# Altium schematic sheet Arak-AnalogueFrontend.SchDoc
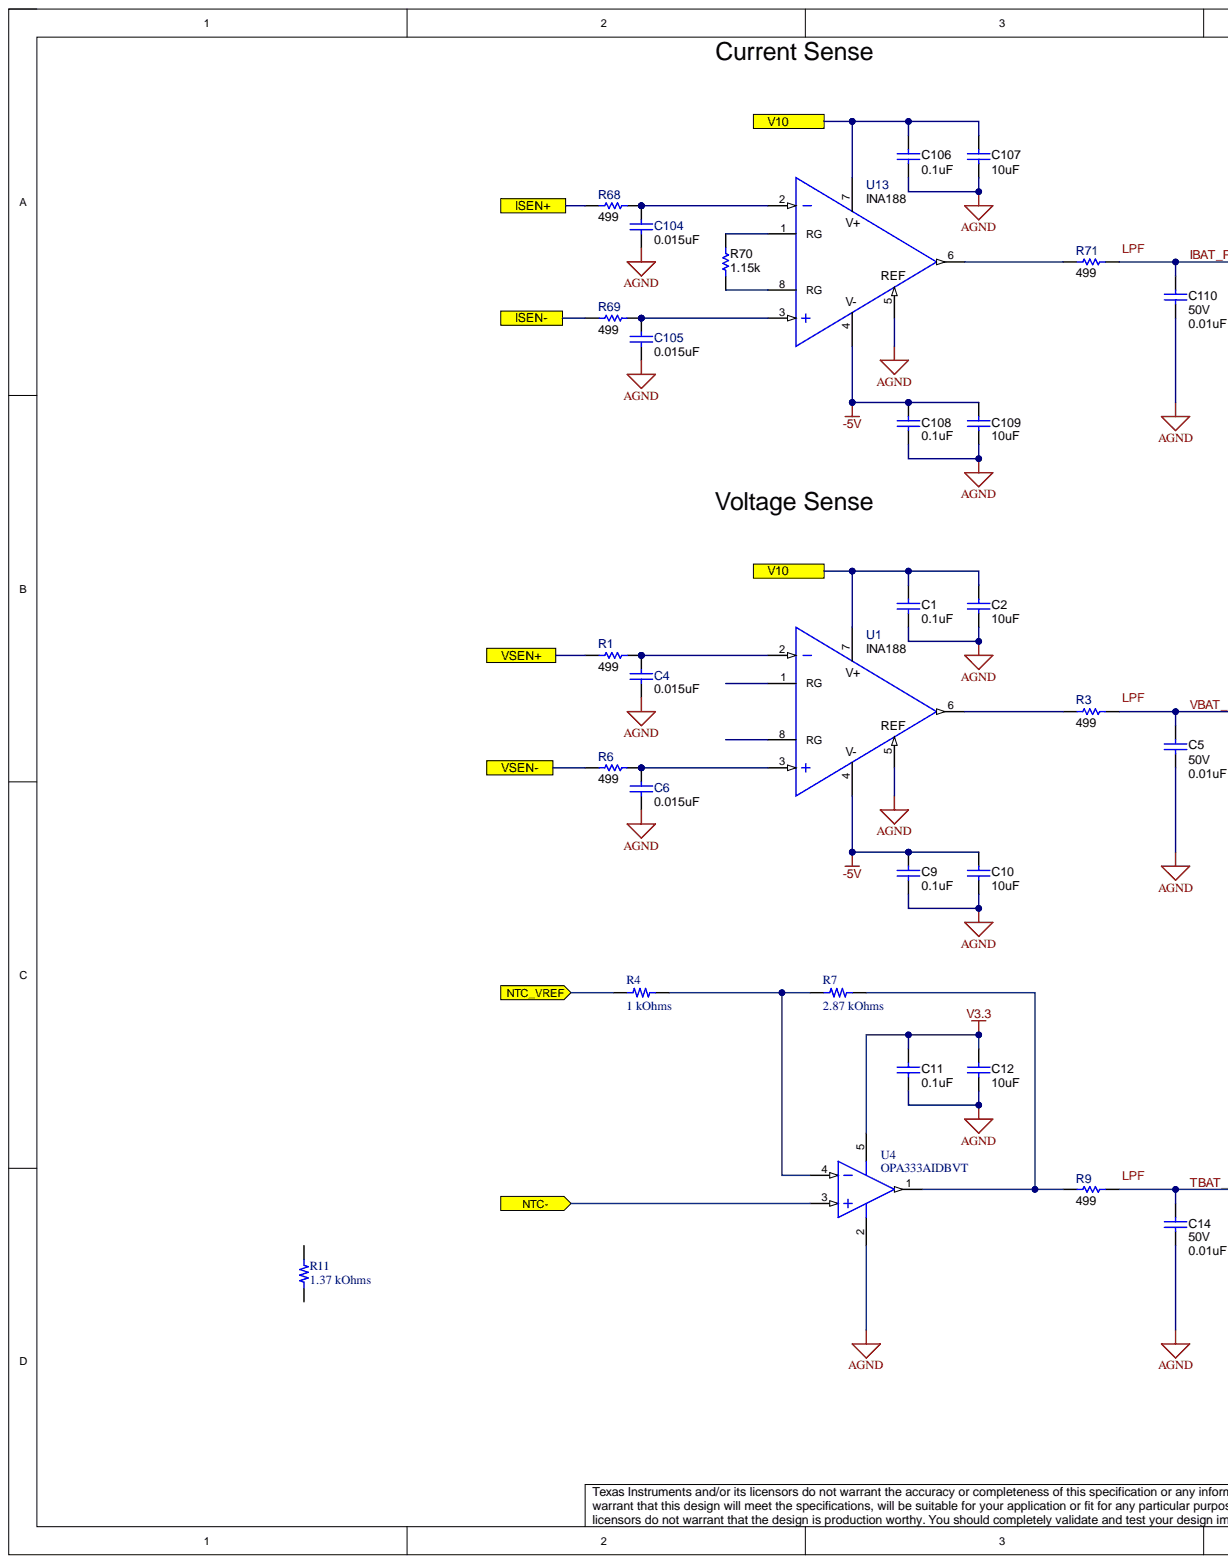
[diagram p0: Arak-AnalogueFrontend.SchDoc, left]
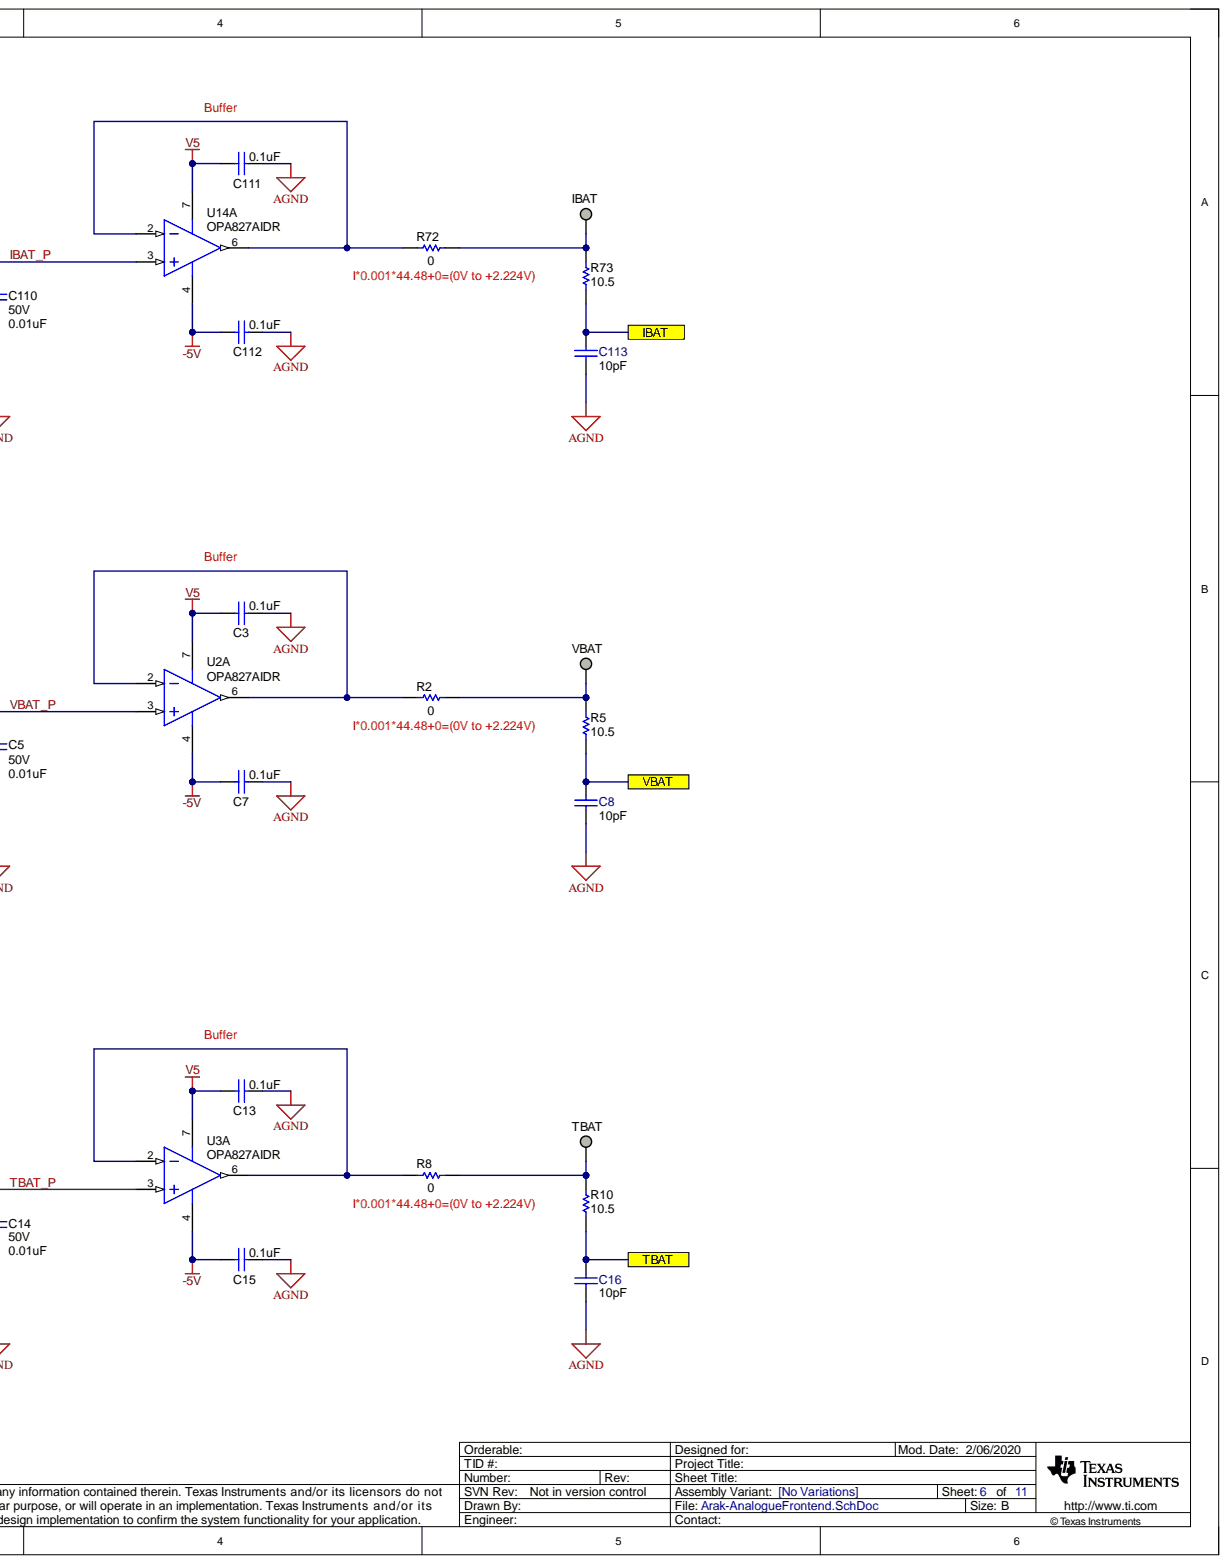
[diagram p1: Arak-AnalogueFrontend.SchDoc, right]

## Parts
C1  value 0.1uF  [0603]  (hidden comment: Capacitor)
C2  value 10uF  [0805_HV]  (hidden comment: Capacitor)
C3  value 0.1uF  [0603]  (hidden comment: Capacitor)
C4  value 0.015uF  [0603]  (hidden comment: Capacitor)
C5  value 0.01uF  Value2: 50V  [0603]  (hidden comment: GCM188R71H103KA37D)
C6  value 0.015uF  [0603]  (hidden comment: Capacitor)
C7  value 0.1uF  [0603]  (hidden comment: Capacitor)
C8  value 10pF  Cap_F: 10pF  [0603]  (hidden comment: Capacitor)
C9  value 0.1uF  [0603]  (hidden comment: Capacitor)
C10  value 10uF  [0805_HV]  (hidden comment: Capacitor)
C11  value 0.1uF  [0603]  (hidden comment: Capacitor)
C12  value 10uF  [0805_HV]  (hidden comment: Capacitor)
C13  value 0.1uF  [0603]  (hidden comment: Capacitor)
C14  value 0.01uF  Value2: 50V  [0603]  (hidden comment: GCM188R71H103KA37D)
C15  value 0.1uF  [0603]  (hidden comment: Capacitor)
C16  value 10pF  Cap_F: 10pF  [0603]  (hidden comment: Capacitor)
C104  value 0.015uF  [0603]  (hidden comment: Capacitor)
C105  value 0.015uF  [0603]  (hidden comment: Capacitor)
C106  value 0.1uF  [0603]  (hidden comment: Capacitor)
C107  value 10uF  [0805_HV]  (hidden comment: Capacitor)
C108  value 0.1uF  [0603]  (hidden comment: Capacitor)
C109  value 10uF  [0805_HV]  (hidden comment: Capacitor)
C110  value 0.01uF  Value2: 50V  [0603]  (hidden comment: GCM188R71H103KA37D)
C111  value 0.1uF  [0603]  (hidden comment: Capacitor)
C112  value 0.1uF  [0603]  (hidden comment: Capacitor)
C113  value 10pF  Cap_F: 10pF  [0603]  (hidden comment: Capacitor)
IBAT  Pogo Pad  [PogoPad]  (value not drawn)  (no BOM)
R1  value 499  [0603]  (hidden comment: Resistor)
R2  value 0  [0603]  (hidden comment: Resistor)
R3  value 499  [0603]  (hidden comment: Resistor)
R4  1 kOhms  [RES 0603_1608]
R5  value 10.5  Res_ohm: 10.5  [0603]  (hidden comment: RC0603FR-0710R5L)
R6  value 499  [0603]  (hidden comment: Resistor)
R7  2.87 kOhms  [RES 0603_1608]
R8  value 0  [0603]  (hidden comment: Resistor)
R9  value 499  [0603]  (hidden comment: Resistor)
R10  value 10.5  Res_ohm: 10.5  [0603]  (hidden comment: RC0603FR-0710R5L)
R11  1.37 kOhms  [RES 0603_1608]
R68  value 499  [0603]  (hidden comment: Resistor)
R69  value 499  [0603]  (hidden comment: Resistor)
R70  1.15k  [0603]
R71  value 499  [0603]  (hidden comment: Resistor)
R72  value 0  [0603]  (hidden comment: Resistor)
R73  value 10.5  Res_ohm: 10.5  [0603]  (hidden comment: RC0603FR-0710R5L)
TBAT  Pogo Pad  [PogoPad]  (value not drawn)  (no BOM)
U1  INA188  [D0008A_N]
U2  OPA827AIDR  [D0008A_L]  (part A)
U3  OPA827AIDR  [D0008A_L]  (part A)
U4  OPA333AIDBVT  [DBV0005A_M]
U13  INA188  [D0008A_N]
U14  OPA827AIDR  [D0008A_L]  (part A)
VBAT  Pogo Pad  [PogoPad]  (value not drawn)  (no BOM)

## Ports
IBAT, ISEN+, ISEN-, NTC-, NTC_VREF, TBAT, V10, VBAT, VSEN+, VSEN-

## Nets
-5V: C7.1 C9.1 C10.1 C15.1 C108.1 C109.1 C112.1 U1.4(V-) U2.4 U3.4 U13.4(V-) U14.4
AGND: C1.2 C2.2 C3.2 C4.2 C5.2 C6.2 C7.2 C8.1 C9.2 C10.2 C11.2 C12.2 C13.2 C14.2 C15.2 C16.1 C104.2 C105.2 C106.2 C107.2 C108.2 C109.2 C110.2 C111.2 C112.2 C113.1 U1.5 U4.2 U13.5
V3.3: C11.1 C12.1 U4.5
V5: C3.1 C13.1 C111.1 U2.7 U3.7 U14.7
IBAT: C113.2 R73.1 ; port IBAT
IBAT_P: C110.1 R71.1 U14.3
NTC-: U4.3 ; port NTC-
NTC_VREF: R4.1 ; port NTC_VREF
TBAT: C16.2 R10.1 ; port TBAT
TBAT_P: C14.1 R9.1 U3.3
V10: C1.1 C2.1 C106.1 C107.1 U1.7(V+) U13.7(V+) ; port V10
VBAT: C8.2 R5.1 ; port VBAT
VBAT_P: C5.1 R3.1 U2.3
NetC4_1: C4.1 R1.1 U1.2
NetC6_1: C6.1 R6.1 U1.3
NetC104_1: C104.1 R68.1 U13.2
NetC105_1: C105.1 R69.1 U13.3
NetIBAT_1: IBAT.1 R72.2 R73.2
NetR1_2: R1.2
NetR2_1: R2.1 U2.2 U2.6
NetR2_2: R2.2 R5.2 VBAT.1
NetR3_2: R3.2 U1.6
NetR4_2: R4.2 R7.1 U4.4
NetR6_2: R6.2
NetR7_2: R7.2 R9.2 U4.1
NetR8_1: R8.1 U3.2 U3.6
NetR8_2: R8.2 R10.2 TBAT.1
NetR68_2: R68.2
NetR69_2: R69.2
NetR70_1: R70.1 U13.8(RG)
NetR70_2: R70.2 U13.1(RG)
NetR71_2: R71.2 U13.6
NetR72_1: R72.1 U14.2 U14.6

Not connected: R11 1 2; U1 1(RG) 8(RG)

## Library data of the parts
C1 C3 C7 C9 C11 C13 C15 C106 C108 C111 C112 = CMP-0006752-5: CAP, CERM, 0.1 uF, 25 V, +/- 5%, X7R, 0603; Manufacturer: AVX; PartNumber: 06033C104JAT2A; AEC Category: Q200; AEC Qualified: N; Cap_F: 100nF; ESR_ohm: 0.05; SizeArea_mm2: 1.28; SizeAreaWB_mm2: 4.68; SizeX_mm: 1.6; SizeY_mm: 0.8; SizeZ_mm: 1; TaMax_C: 125°C; TaMin_C: -55°C; U/M: EA; Value1: 0.05 ohm; Value2: 25V; AEC Grade: N/A; Cap_Case: 0603; Component Kind: Standard; ComponentLink1Description: Datasheet link; ComponentLink1URL: http://datasheets.avx.com/X7RDielectric.pdf; CompType: Capacitor; LatestRevisionDate: 2017-03-09; Library Name: Altium Vault - TI; MountType: SMT; PackageReference: 0603; Polarized: N; Published: 2008-06-19; RoHS: Y; Supplier 1: Digi-Key; Supplier 2: Mouser; Supplier 3: Farnell; Supplier Part Number 1: 478-3713-1-ND; Supplier Part Number 2: 581-06033C104JAT2A; Supplier Part Number 3: 1740614; Technology: Ceramic; TempCo: X7R; Tolerance_%: 5%; ValueDisplayed: 0.1uF; VDC_V: 25V; Publisher: Texas Instruments
C2 C10 C12 C107 C109 = CMP-0008315-2: CAP, CERM, 10 uF, 10 V, +/- 10%, X7R, 0805; Manufacturer: MuRata; PartNumber: GRM21BR71A106KE51L; AEC Category: Q200; Cap_Case: 0805; Cap_F: 10µF; ESR_ohm: 3m; Polarized: N; SizeArea_mm2: 2.5; SizeAreaWB_mm2: 6.75; SizeX_mm: 2; SizeY_mm: 1.25; SizeZ_mm: 1.45; TaMax_C: 125°C; TaMin_C: -55°C; U/M: EA; Value1: 0.003 ohm; Value2: 10V; AEC Qualified: ?; Component Kind: Standard; ComponentLink1Description: Datasheet link; ComponentLink1URL: http://search.murata.co.jp/Ceramy/PnsearchViewAction.do?HnOFG=ON&HnNFG=ON&SEL_CNT=50&sLang=en&sKey=GRM21BR71A106KE51L&x=9&y=9&search=startwith; CompType: Capacitor; LatestRevisionDate: 2017-03-09; Library Name: Altium Vault - TI; MountType: SMT; PackageReference: 0805; Published: 2008-06-19; RoHS: Y; Supplier 1: Digi-Key; Supplier 2: Mouser; Supplier 3: Farnell; Supplier Part Number 1: 490-3905-1-ND; Supplier Part Number 2: 81-GRM21BR71A106KE51; Supplier Part Number 3: 1828828; Technology: Ceramic; TempCo: X7R; Tolerance_%: 10%; ValueDisplayed: 10uF; VDC_V: 10V; Publisher: Texas Instruments
C4 C6 C104 C105 = CMP-0007383-5: CAP, CERM, 0.015 uF, 16 V, +/- 10%, X7R, 0603; Manufacturer: MuRata; PartNumber: GRM188R71C153KA01D; AEC Category: Q200; Cap_F: 15nF; Polarized: N; SizeArea_mm2: 1.28; SizeAreaWB_mm2: 4.68; SizeX_mm: 1.6; SizeY_mm: 0.8; SizeZ_mm: 1; TaMax_C: 125°C; TaMin_C: -55°C; U/M: EA; Value1: Unknown; Value2: 16V; AEC Qualified: ?; Cap_Case: 0603; Component Kind: Standard; ComponentLink1Description: Datasheet link; ComponentLink1URL: http://search.murata.co.jp/Ceramy/PnsearchViewAction.do?HnOFG=ON&HnNFG=ON&SEL_CNT=50&sLang=en&sKey=GRM188R71C153KA01D&x=9&y=9&search=startwith; CompType: Capacitor; LatestRevisionDate: 2017-03-09; Library Name: Altium Vault - TI; MountType: SMT; PackageReference: 0603; Published: 2008-06-19; RoHS: Y; Supplier 1: Mouser; Supplier Part Number 1: 81-GRM188R71C153KA; Technology: Ceramic; TempCo: X7R; Tolerance_%: 10%; ValueDisplayed: 0.015uF; VDC_V: 16V; Publisher: Texas Instruments
C5 C14 C110 = CMP-0007143-4: CAP, CERM, 0.01 uF, 50 V, +/- 10%, X7R, AEC-Q200 Grade 1, 0603; Manufacturer: MuRata; AEC Category: Q200; AEC Grade: 1; AEC Qualified: Y; Cap_F: 10nF; CompType: Capacitor; Polarized: N; SizeArea_mm2: 1.28; SizeAreaWB_mm2: 4.68; SizeX_mm: 1.6; SizeY_mm: 0.8; TaMax_C: 125°C; TaMin_C: -55°C; U/M: EA; Value1: Unknown; Cap_Case: 0603; Component Kind: Standard; ComponentLink1Description: Datasheet link; ComponentLink1URL: http://psearch.en.murata.com/capacitor/product/GCM188R71H103KA37%23.pdf; LatestRevisionDate: 2017-03-09; Library Name: Altium Vault - TI; MountType: SMT; PackageReference: 0603; PartNumber: GCM188R71H103KA37D; Published: 2008-06-19; RoHS: Y; SizeZ_mm: 1; Supplier 1: Digi-Key; Supplier 2: Newark; Supplier 3: Mouser; Supplier Part Number 1: 490-4778-1-ND; Supplier Part Number 2: 45Y5166; Supplier Part Number 3: 81-GCM188R71H103KA7D; Technology: Ceramic; TempCo: X7R; Tolerance_%: 10%; ValueDisplayed: 0.01uF; VDC_V: 50V; Publisher: Texas Instruments
C8 C16 C113 = CMP-0007170-4: CAP, CERM, 10 pF, 50 V, +/- 5%, C0G/NP0, 0603; Manufacturer: MuRata; PartNumber: GRM1885C1H100JA01D; AEC Category: Q200; Polarized: N; SizeArea_mm2: 1.28; SizeAreaWB_mm2: 4.68; SizeX_mm: 1.6; SizeY_mm: 0.8; SizeZ_mm: 1; TaMax_C: 125°C; TaMin_C: -55°C; U/M: EA; Value1: Unknown; Value2: 50V; AEC Qualified: ?; Cap_Case: 0603; Component Kind: Standard; ComponentLink1Description: Datasheet link; ComponentLink1URL: http://search.murata.co.jp/Ceramy/PnsearchViewAction.do?HnOFG=ON&HnNFG=ON&SEL_CNT=50&sLang=en&sKey=GRM1885C1H100JA01D&x=9&y=9&search=startwith; CompType: Capacitor; LatestRevisionDate: 2017-03-09; Library Name: Altium Vault - TI; MountType: SMT; PackageReference: 0603; Published: 2008-06-19; RoHS: Y; Supplier 1: Digi-Key; Supplier 2: Mouser; Supplier 3: Farnell; Supplier Part Number 1: 490-1403-1-ND; Supplier Part Number 2: 81-GRM39C100D50; Supplier Part Number 3: 8819815; Technology: Ceramic; TempCo: C0G/NP0; Tolerance_%: 5%; ValueDisplayed: 10pF; VDC_V: 50V; Publisher: Texas Instruments
IBAT TBAT VBAT = PogoPad: Pad for Pogo Pin Connector; SymbolCreator: codlsc; CompType: Pogo Pad; LatestRevisionDate: 2016-12-05; LatestRevisionNote: Added Alternate PartNumber and Alternate Manufacturer parameters to appropriate components.; Library Name: MiscParts.IntLib; PackageReference: N/A; SymbolVersion: 2010-09-27; Publisher: Texas Instruments
R1 R3 R6 R9 R68 R69 R71 = CMP-0022446-5: RES, 499, 1%, 0.1 W, AEC-Q200 Grade 0, 0603; Manufacturer: Vishay-Dale; PartNumber: CRCW0603499RFKEA; AEC Category: Q200; AEC Grade: 0; AEC Qualified: Y; EIA_Code: E96; Power_W: 100mW; SizeArea_mm2: 1.28; SizeX_mm: 1.6; SizeY_mm: 0.8; SizeZ_mm: 1; TempCo: 100 ppm/C; Value2: 0.1W; Value_Code: 499R; VDC_V: 75V; Component Kind: Standard; ComponentLink1Description: Datasheet link; ComponentLink1URL: http://www.vishay.com/docs/20035/dcrcwe3.pdf; CompType: Resistor; Library Name: Altium Vault - TI; MountType: SMT; PackageReference: 0603; Res_ohm: 499; RoHS: E; Supplier 1: Digi-Key; Supplier 2: Newark; Supplier 3: Mouser; Supplier Part Number 1: 541-499HCT-ND; Supplier Part Number 2: 52K8569; Supplier Part Number 3: 71-CRCW0603-499-E3; Technology: Thick Film; Tolerance_%: 1%; Value1: 1%; ValueDisplayed: 499
R2 R8 R72 = CMP-0025874-3: RES, 0, 5%, 0.1 W, 0603; Manufacturer: Panasonic; PartNumber: ERJ-3GEY0R00V; AEC Category: Q200; EIA_Code: E24; Power_W: 100mW; SizeArea_mm2: 1.28; SizeX_mm: 1.6; SizeY_mm: 0.8; SizeZ_mm: 1; TempCo: 600 ppm/C; U/M: EA; Value2: 0.1W; Value_Code: 0000; VDC_V: 50V; AEC Qualified: ?; Component Kind: Standard; ComponentLink1Description: Datasheet link; ComponentLink1URL: http://www.panasonic.com/industrial/components/pdf/AOA0000CE1.pdf; CompType: Resistor; LatestRevisionDate: 2017-03-09; Library Name: Altium Vault - TI; MountType: SMT; PackageReference: 0603; Published: 2008-06-26; Res_ohm: 0; RoHS: Y; Supplier 1: Digi-Key; Supplier 2: Mouser; Supplier 3: Farnell; Supplier Part Number 1: P0.0GCT-ND; Supplier Part Number 2: 667-ERJ-3GEY0R00V; Supplier Part Number 3: 2059527; Technology: Thick Film; Tolerance_%: 5%; Value1: 5%; ValueDisplayed: 0; Publisher: Texas Instruments
R4 = TNPW06031K00BYEN: RES 1K OHM 0.1% 1/8W 0603; Manufacturer: Vishay Dale; Database Table Name: Resistors - Surface Mount - 0603; Power (Watts): 0.125W, 1/8W; Temperature Coefficient: ±10ppm/°C; Component Kind: Standard; ComponentLink1Description: Datasheet; ComponentLink1URL: http://www.vishay.com/docs/28758/tnpw_e3.pdf; ComponentLink2Description: DigiKey Link; ComponentLink2URL: http://digikey.com/product-detail/en/vishay-dale/TNPW06031K00BYEN/541-4408-1-ND/8629044; Composition: Thin Film; Device Package: 0603 (1608 Metric); Features: Anti-Sulfur, Automotive AEC-Q200, Moisture Resistant; Height - Seated (Max): 0.022" (0.55mm); LastUpdated: 21/01/2020 12:18:21 AM; Library Name: Celestial Altium Library - altium_library.DbLib; Lifecycle Status: Active; Minimum Order: 1; Number of Terminations: 2; Operating Temperature: -55°C ~ 155°C; Package / Case: 0603 (1608 Metric); Packaging: TapeAndReel; Part Number: TNPW06031K00BYEN; Part Status: Active; PartId: 21027; Price: 0.27; Ratings: AEC-Q200; Resistance: 1 kOhms; Series: TNPW e3; Size / Dimension: 0.061" L x 0.033" W (1.55mm x 0.85mm); Supplier 1: DigiKey; Supplier Device Package: 0603; Supplier Part Number 1: 541-4408-1-ND; Tolerance: ±0.1%; Value: 1 kOhms
R5 R10 R73 = CMP-0022749-2: RES, 10.5, 1%, 0.1 W, 0603; Manufacturer: Yageo America; AEC Category: Q200; CompType: Resistor; EIA_Code: E96; Power_W: 100mW; SizeArea_mm2: 1.28; SizeX_mm: 1.6; SizeY_mm: 0.8; SizeZ_mm: 1; TempCo: 200 ppm/C; U/M: EA; Value2: 0.1W; Value_Code: 10R5; VDC_V: 150V; AEC Qualified: ?; Component Kind: Standard; ComponentLink1Description: Datasheet link; ComponentLink1URL: http://www.yageo.com/pdf/Pu-RC0603_51_PbFree_L_2.pdf; LatestRevisionDate: 2017-03-09; Library Name: Altium Vault - TI; MountType: SMT; PackageReference: 0603; PartNumber: RC0603FR-0710R5L; Published: 2008-06-26; RoHS: Y; Supplier 1: Digi-Key; Supplier 2: Mouser; Supplier 3: Farnell; Supplier Part Number 1: 311-10.5HRCT-ND; Supplier Part Number 2: 603-RC0603FR-0710R5L; Supplier Part Number 3: 9238247; Technology: Thick Film; Tolerance_%: 1%; Value1: 1%; ValueDisplayed: 10.5; Publisher: Texas Instruments
R7 = TNPW06032K87BEEA: RES 2.87K OHM 0.1% 1/8W 0603; Manufacturer: Vishay Dale; Database Table Name: Resistors - Surface Mount - 0603; Power (Watts): 0.125W, 1/8W; Temperature Coefficient: ±25ppm/°C; Component Kind: Standard; ComponentLink1Description: Datasheet; ComponentLink1URL: http://www.vishay.com/docs/28758/tnpw_e3.pdf; ComponentLink2Description: DigiKey Link; ComponentLink2URL: http://digikey.com/product-detail/en/vishay-dale/TNPW06032K87BEEA/541-3180-1-ND/6532822; Composition: Thin Film; Device Package: 0603 (1608 Metric); Features: Anti-Sulfur, Automotive AEC-Q200, Moisture Resistant; Height - Seated (Max): 0.022" (0.55mm); LastUpdated: 21/01/2020 12:17:06 AM; Library Name: Celestial Altium Library - altium_library.DbLib; Lifecycle Status: Active; Minimum Order: 1; Number of Terminations: 2; Operating Temperature: -55°C ~ 155°C; Package / Case: 0603 (1608 Metric); Packaging: TapeAndReel; Part Number: TNPW06032K87BEEA; Part Status: Active; PartId: 23190; Price: 0.11; Ratings: AEC-Q200; Resistance: 2.87 kOhms; Series: TNPW e3; Size / Dimension: 0.063" L x 0.033" W (1.60mm x 0.85mm); Supplier 1: DigiKey; Supplier Device Package: 0603; Supplier Part Number 1: 541-3180-1-ND; Tolerance: ±0.1%; Value: 2.87 kOhms
R11 = TNPW06031K37BEEA: RES 1.37K OHM 0.1% 1/8W 0603; Manufacturer: Vishay Dale; Database Table Name: Resistors - Surface Mount - 0603; Power (Watts): 0.125W, 1/8W; Temperature Coefficient: ±25ppm/°C; Component Kind: Standard; ComponentLink1Description: Datasheet; ComponentLink1URL: http://www.vishay.com/docs/28758/tnpw_e3.pdf; ComponentLink2Description: DigiKey Link; ComponentLink2URL: http://digikey.com/product-detail/en/vishay-dale/TNPW06031K37BEEA/541-3048-1-ND/6073691; Composition: Thin Film; Device Package: 0603 (1608 Metric); Features: Anti-Sulfur, Automotive AEC-Q200, Moisture Resistant; Height - Seated (Max): 0.022" (0.55mm); LastUpdated: 21/01/2020 12:14:57 AM; Library Name: Celestial Altium Library - altium_library.DbLib; Lifecycle Status: Active; Minimum Order: 1; Number of Terminations: 2; Operating Temperature: -55°C ~ 155°C; Package / Case: 0603 (1608 Metric); Packaging: TapeAndReel; Part Number: TNPW06031K37BEEA; Part Status: Active; PartId: 25753; Price: 0.11; Ratings: AEC-Q200; Resistance: 1.37 kOhms; Series: TNPW e3; Size / Dimension: 0.063" L x 0.033" W (1.60mm x 0.85mm); Supplier 1: DigiKey; Supplier Device Package: 0603; Supplier Part Number 1: 541-3048-1-ND; Tolerance: ±0.1%; Value: 1.37 kOhms
R70 = CMP-0023872-3: RES, 1.15 k, 0.1%, 0.1 W, 0603; Manufacturer: Yageo America; PartNumber: RT0603BRD071K15L; AEC Category: Q200; CompType: Resistor; EIA_Code: E192; Power_W: 100mW; SizeArea_mm2: 1.28; SizeX_mm: 1.6; SizeY_mm: 0.8; SizeZ_mm: 1; TempCo: 25 ppm/C; U/M: EA; Value2: 0.1W; Value_Code: 1k15; VDC_V: 75V; AEC Qualified: ?; Component Kind: Standard; ComponentLink1Description: Datasheet link; ComponentLink1URL: http://www.yageo.com/pdf/PYu-RT_1-to-0.05_RoHS_L_3.pdf; LatestRevisionDate: 2017-03-09; Library Name: Altium Vault - TI; MountType: SMT; PackageReference: 0603; Published: 2008-06-26; Res_ohm: 1.15k; RoHS: Y; Technology: Thin Film; Tolerance_%: 0.1%; Value: 1.15k; Value1: 0.1%; ValueDisplayed: 1.15k; Publisher: Texas Instruments
U1 U13 = CMP-0075744-1: 36-V, Zero-Drift, Rail-to-Rail-Out Instrumentation Amplifier, D0008A (SOIC-8); Alternate Manufacturer: Texas Instruments; Alternate PartNumber: INA188ID; PartNumber: INA188IDR; CompType: IC; SizeArea_mm2: 30.38; SizeAreaWB_mm2: 56.58; SizeX_mm: 4.9; SizeY_mm: 6.2; SizeZ_mm: 1.75; U/M: EA; Component Kind: Standard; ComponentLink1Description: Datasheet Link; ComponentLink1URL: http://www.ti.com/lit/ds/symlink/ina188.pdf; LatestRevisionDate: 2017-06-09; Library Name: Altium Vault - TI; Manufacturer: Texas Instruments; MountType: SMT; PackageDescription: SOIC-8; PackageReference: D0008A; RoHS: Y; Publisher: Texas Instruments
U2 U3 U14 = CMP-0041267-2: Low-Noise, High-Precision, JFET-Input Operational Amplifier, 8 to 36 V, -40 to 125 degC, 8-pin SOIC (D8), Green (RoHS & no Sb/Br); Manufacturer: Texas Instruments; GBW(Typ)(MHz): 22; Iq per channel(Max)(mA): 5.2; Number of Channels: 1; SubFamily: Audio Operational Amplifier; Vmax(V): 36; Vmin(V): 8; Vn at Flatband(Typ)(nV/rtHz): 4; Code_JEDEC: MS-012; Component Kind: Standard; ComponentLink1Description: Manufacturer URL; ComponentLink1URL: http://www.ti.com/; ComponentLink2Description: Datasheet; ComponentLink2URL: http://www.ti.com/general/docs/lit/getliterature.tsp?genericPartNumber=OPA827&fileType=pdf; ComponentLink3Description: Package Specification; ComponentLink3URL: http://www.ti.com/litv/pdf/msoi002j; DatasheetVersion: SBOS376H; Eco-Plan: Green (RoHS & no Sb/Br); Library Name: Altium Vault - TI; Mounting Technology: Surface Mount; PackageDescription: 8-Pin Plastic Small Outline, Body 4.9 x 3.9 mm, Pitch 1.27 mm; PackageReference: D0008A; PackageVersion: 4040047-3/M, 06/2011; PartNumber: OPA827AIDR
U4 = CMP-0272-00841-3: 17 uA, MicroPower, Precision, Zero Drift CMOS Operational Amplifier, 1.8 to 5.5 V, -40 to 125 degC, 5-pin SOT23 (DBV5), Green (RoHS & no Sb/Br); Manufacturer: Texas Instruments; CMRR(Min)(dB): 106; IIB(Max)(pA): 200; Iq per channel(Max)(mA): 0.025; Number of Channels: 1; Offset Drift(Typ)(uV/C): 0.02; Slew Rate(Typ)(V/us): 0.16; Sub Family: Low Offset Voltage Amplifier (<=500uV), Low Power Amp (<=500uA), Low Supply Voltage Amplifier (<=2.7V), Precision Amplifier, Zero Drift Precision Amplifier; Total Supply Voltage(Max)(+5V is 5, +/-5V is 10): 5.5; Total Supply Voltage(Min)(+5V is 5, +/-5V is 10): 1.8; Vio (25C)(Max)(mV): 0.01; Code_JEDEC: MO-178; Component Kind: Standard; ComponentLink1Description: Manufacturer URL; ComponentLink1URL: http://www.ti.com/; ComponentLink2Description: Datasheet; ComponentLink2URL: http://www.ti.com/general/docs/lit/getliterature.tsp?genericPartNumber=OPA333&fileType=pdf; ComponentLink3Description: Package Specification; ComponentLink3URL: http://www.ti.com/litv/pdf/mpds018i; DatasheetVersion: SBOS351C; Eco-Plan: Green (RoHS & no Sb/Br); Library Name: Altium Content Vault; Mounting Technology: Surface Mount; PackageDescription: 5-Pin Plastic Small Outline, Body 1.6 x 2.9 mm, Pitch 0.95 mm; PackageReference: DBV0005A; PackageVersion: 4073253-4/K, 03/2006; PartNumber: OPA333AIDBVT
